# Altium schematic sheet JacdacPinHeaders 45.SchDoc
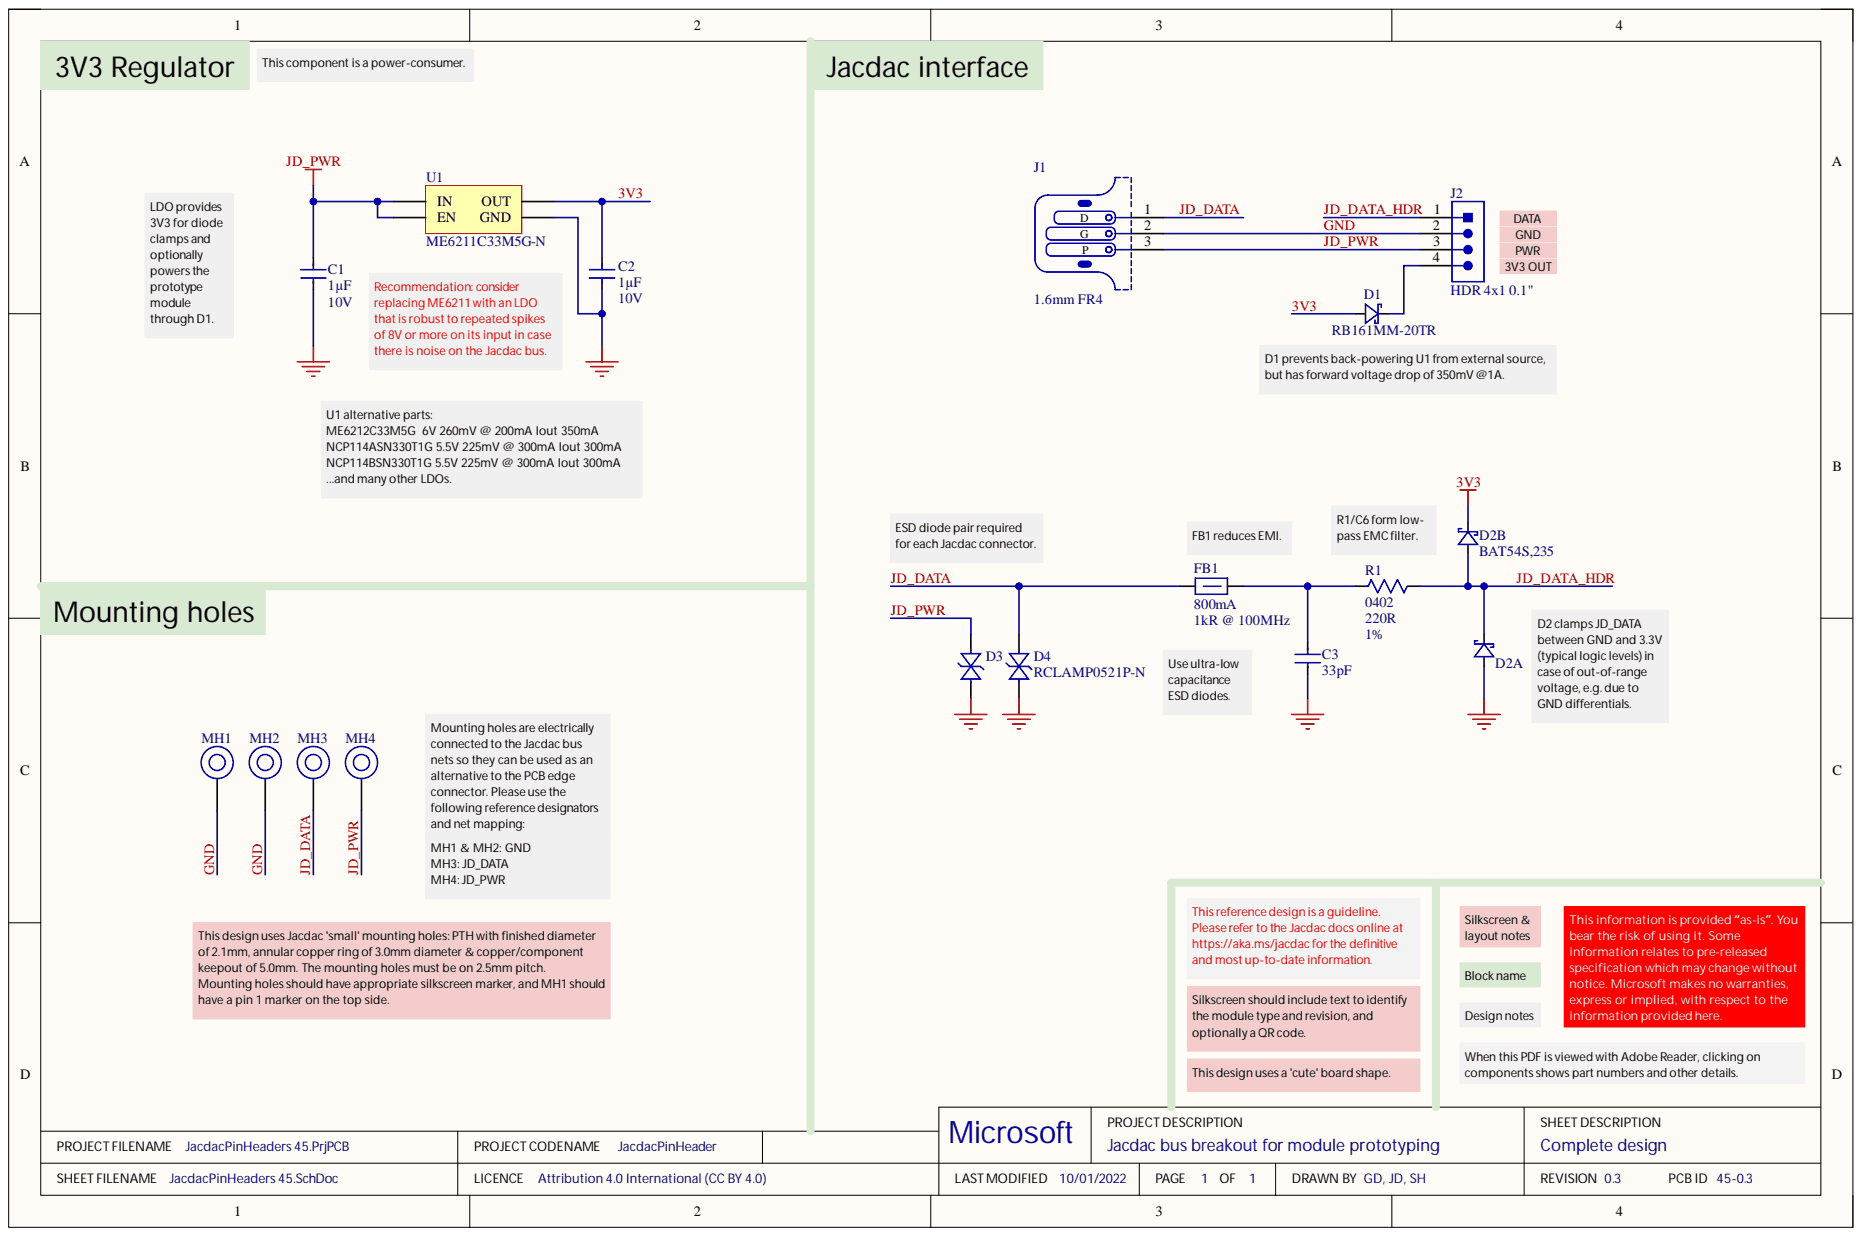
[diagram p0: JacdacPinHeaders 45.SchDoc]

## Parts
C1  Capacitance: 1µF  Voltage Rating: 10V  [C0402_0.55MM - without outline]  (hidden comment: CL05A105KP5NNNC)
C2  Capacitance: 1µF  Voltage Rating: 10V  [C0402_0.55MM - without outline]  (hidden comment: CL05A105KP5NNNC)
C3  Capacitance: 33pF  [C0402_0.55MM - without outline]  (hidden comment: CL05C330JB51PNC)
D1  RB161MM-20TR  [SOD-123F]
D2  BAT54S  Manufacturer Part Number: BAT54S,235  [NXP-BAT54S-TO-236AB - without outline]  (parts A,B)
D3  RCLAMP0521P-N  [TVS 0402 - L]
D4  RCLAMP0521P-N  [TVS 0402 - L]
FB1  Current Rating: 800mA  [TDK MPZ1608 0.8mm - without outline]  (hidden comment: TDK MPZ1608S102ATA00)
J1  PCB Type: 1.6mm FR4  [JACDAC_EDGE_CONNECTOR_NO_TRACES]  (hidden comment: 3 pin JACDAC edge connector)  (no BOM)
J2  HDR 4x1 0.1"  [Generic 1x4 2.54mm header]
MH1  MH_5.0MMK_3.0MMC_2.1MMD_PTH  [MH_5.0MMK_3.0MMC_2.1MMD_PTH]  (value not drawn)  (no BOM)
MH2  MH_5.0MMK_3.0MMC_2.1MMD_PTH  [MH_5.0MMK_3.0MMC_2.1MMD_PTH]  (value not drawn)  (no BOM)
MH3  MH_5.0MMK_3.0MMC_2.1MMD_PTH  [MH_5.0MMK_3.0MMC_2.1MMD_PTH]  (value not drawn)  (no BOM)
MH4  MH_5.0MMK_3.0MMC_2.1MMD_PTH  [MH_5.0MMK_3.0MMC_2.1MMD_PTH]  (value not drawn)  (no BOM)
R1  Case Code (Imperial): 0402  Resistance: 220R  [R0402 - Without outline]  (hidden comment: RC0402FR-07220RL)
U1  ME6211C33M5G-N  [MICRONE-SOT23-5]

## Nets
3V3: C2.2 D1.2 D2.2 U1.5(OUT)
GND: C1.1 C2.1 C3.1 D2.1 D3.2 D4.2 J1.2 J2.2 MH1.1 MH2.1 U1.2(GND)
JD_DATA: D4.1 FB1.1 J1.1 MH3.1
JD_DATA_HDR: D2.3 J2.1 R1.1
JD_PWR: C1.2 D3.1 J1.3 J2.3 MH4.1 U1.1(IN) U1.3(EN)
NetC3_2: C3.2 FB1.2 R1.2
NetD1_1: D1.1 J2.4

## Library data of the parts
C1 C2 = CL05A105KP5NNNC: CAP CER 1UF 10V X5R 0402; Manufacturer: Samsung; Material: Ceramic; Tolerance: 10%; Case Code (Imperial): 0402; Case Code (Metric): 1005; Case/Package: 0402; Category: Capacitors; Component Kind: Standard; ComponentLink1Description: Online datasheet; ComponentLink1URL: https://datasheet.ciiva.com/15195/getdatasheetpartid-358390-15195630.pdf; Contact Plating: Tin; Depth: 0.5mm; Dielectric: X5R; Height: 0.5mm; Length: 1mm; Library Name: Samsung.SchLib; Manufacturer Part Number: CL05A105KP5NNNC; Max Operating Temperature: 85°C; Min Operating Temperature: -55°C; Mount: Surface Mount; Package Quantity: 1; Packaging: Tape and Reel; Pricing 1: 1=0.10000, 10=0.03900, 50=0.02100, 100=0.01720, 500=0.01228, 1000=0.00965, 2500=0.00877, 5000=0.00807 (USD); Pricing 2: 1=0.10000, 10=0.04200, 100=0.01800, 500=0.01300, 1000=0.01000, 2500=0.00900 (USD); Pricing 3: 10=0.02450, 100=0.02000, 500=0.01310, 2500=0.00920, 5000=0.00810, 10000=0.00640, 50000=0.00490 (GBP); Radiation Hardening: No; RoHS: Yes; Stock 1: 5705569; Stock 2: 928941; Stock 3: 53670; Supplier 1: Digi-Key; Supplier 2: Mouser; Supplier 3: Farnell; Supplier Part Number 1: 1276-1076-1-ND; Supplier Part Number 2: 187-CL05A105KP5NNNC; Supplier Part Number 3: 3013329; Thickness: 0.022inch; Width: 0.02inch; Checked: ?; Created: DG
C3 = CL05C330JB51PNC: CAP CER 33PF 50V NP0 0402; Manufacturer: Samsung; Tolerance: 5%; Case Code (Imperial): 0402; Case Code (Metric): 1005; Case/Package: 0402; Category: Capacitors; Component Kind: Standard; ComponentLink1Description: Samsung datasheet online; ComponentLink1URL: http://www.samsungsem.com/kr/support/library/product-catalog/mlcc.jsp; Lead Free: Lead Free; Length: 0.039inch; Library Name: Samsung.SchLib; Manufacturer Part Number: CL05C330JB51PNC; Max Operating Temperature: 125°C; Min Operating Temperature: -55°C; Mount: Surface Mount; Packaging: Tape and Reel; Pricing 1: 1=0.10000, 10=0.07500, 50=0.04060, 100=0.03320, 500=0.02374, 1000=0.01865, 2500=0.01696, 5000=0.01560 (USD); Pricing 2: 1=0.11000, 10=0.08200, 100=0.03600, 500=0.02600, 1000=0.02000, 2500=0.01800 (USD); Pricing 3: 10000=0.00780 (USD); Ratings: AEC-Q200; RoHS: Yes; Stock 1: 250654; Stock 2: 97900; Stock 3: 100000; Supplier 1: Digi-Key; Supplier 2: Mouser; Supplier 3: Future Electronics; Supplier Part Number 1: 1276-6515-1-ND; Supplier Part Number 2: 187-CL05C330JB51PNC; Supplier Part Number 3: 6022520; Thickness: 0.022inch; Voltage Rating: 50V; Width: 0.02inch; Checked: ?; Created: DG
D1 = RB161MM-20TR: ROHM - RB161MM-20TR - Schottky-Gleichrichterdiode, 20 V, 1 A, Einfach, SOD-123FL, 2 Pin(s), 350 mV; Average Rectified Current: 1A; Max Reverse Voltage (DC): 25V; Case/Package: SOD; Category: Rectifier Diodes; Component Kind: Standard; ComponentLink1Description: Online datasheet; ComponentLink1URL: http://www.mouser.com/ds/2/348/rb161mm-20tr-e-1138856.pdf; Library Name: Rohm.SchLib; Manufacturer: Rohm; Manufacturer Part Number: RB161MM-20TR; Max Operating Temperature: 125°C; Mount: Surface Mount; Number of Pins: 2; Packaging: Tape and Reel; Pricing 1: 3000=0.09900, 6000=0.09350, 15000=0.08525, 30000=0.07975, 75000=0.07500 (USD); Pricing 2: 30=0.29200, 150=0.15800, 300=0.15300, 750=0.14900, 1500=0.14500 (USD); Pricing 3: 1=0.33000, 10=0.25100, 50=0.25100, 100=0.12500, 1000=0.10000, 10000=0.07600 (USD); RoHS: Yes; RoHS Compliant: Yes; Stock 2: 1; Supplier 1: Digi-Key; Supplier 2: RSComponents; Supplier 3: Mouser; Supplier Part Number 1: RB161MM-20TR-ND; Supplier Part Number 2: 1246775; Supplier Part Number 3: 755-RB161MM-20TR
D2 = BAT54S: DIODE ARRAY SCHOTTKY 30V SOT23; Manufacturer: Nexperia; Category: Rectifier Diodes; Component Kind: Standard; ComponentLink1Description: NXP datasheet online; ComponentLink1URL: https://assets.nexperia.com/documents/data-sheet/BAT54_SER.pdf; Library Name: NXP.SchLib; Pricing 1: 1=0.13000, 10=0.11900, 100=0.05610, 500=0.03326, 1000=0.02185, 5000=0.02138 (USD); RoHS: No; Stock 1: 14011; Supplier 1: Digi-Key; Supplier 2: Mouser; Supplier 3: RSComponents; Supplier Part Number 1: 1727-1868-1-ND; Supplier Part Number 2: 771-BAT54S235; Supplier Part Number 3: 1707990
D3 D4 = RCLAMP0521P-N: Bidirectional 5V (Max) 6V 16V 3.5A (8/20us) 0402 TVS RoHS; Manufacturer: Born Semiconductor; Component Kind: Standard; ComponentLink1Description: Online datasheet; ComponentLink1URL: https://datasheet.lcsc.com/szlcsc/Bourne-Semicon-Shenzhen-RCLAMP0521P-N_C316043.pdf; Library Name: BornSemiconductor.SchLib; Manufacturer Part Number: RCLAMP0521P-N; Package: 0402; Supplier1: LCSC; Supplier1 Part Number: C316043; Checked By: ?; Created By: D Gakure
FB1 = TDK MPZ1608S102ATA00: 0603 1k@100MHz 0.8A chip; Core Material: Ferrite; DC Resistance (DCR): 300mR; Impedance: 1kR @ 100MHz; Tolerance: 25%; Case Code (Imperial): 0603; Case Code (Metric): 1608; Case/Package: 0603; Component Kind: Standard; ComponentLink2Description: datasheet online; ComponentLink2URL: https://www.mouser.co.uk/datasheet/2/400/eads_commercial_power_mpz1608_en-923650.pdf; ComponentLink3Description: datasheet; Height: 0.037inch; Length: 0.063inch; Library Name: TDK.SchLib; Manufacturer: TDK; Manufacturer Part Number: MPZ1608S102ATA00; Max DC Current: 800mA; Mount: Surface Mount; Package Quantity: 4000; Packaging: Tape and Reel; Pricing 1: 1=0.1, 10=0.079, 25=0.0716, 50=0.0644, 100=0.0472, 250=0.03432, 500=0.03146, 1000=0.02717 (USD); Stock 1: 113542; Supplier 1: Digi-Key; Supplier 2: Mouser; Supplier Part Number 1: 445-8694-1-ND; Supplier Part Number 2: 810-MPZ1608S102ATA00; Width: 0.031inch; ComponentLink3URL: Y:\System\Altium\Libraries 2014\TDK\Datasheets\eads_commercial_power_mpz1608_en-923650.pdf
J1 = Jacdac edge connector: 3 pin Jacdac edge connector 1.27mm pitch; Manufacturer Part Number: JACDAC_EDGE_CONNECTOR; ComponentLink1Description: Yuliang JACDAC cable connector drawing online; ComponentLink1URL: https://github.com/microsoft/jacdac-mdk/blob/main/connector/JACDAC-Connector-by-Yuliang-2020-11-28.pdf; ComponentLink2Description: JACDAC PCB edge connector spec onlin; ComponentLink2URL: https://github.com/microsoft/jacdac-mdk/blob/main/connector/JACDAC_PCB_Edge_Connector_Drawing_JD-PEC-01_rev_01.pdf; Library Name: generic-con.SchLib; Checked By: ?; Created By: D. Gakure
J2 = Header Multicomp 2211S-04G-whitesch: 2.54mm, 4x1 way, vertical, square pin, gold plate; Contact Resistance: 20; Contact Termination: Solder; Contact Termination Type: Through Hole; Current Rating: 3; Gender: Header; Insulation Resistance: 1000; No. of Contacts: 4; No. of Rows: 1; Pitch Spacing: 2.54; Product Range: 2211; SVHC: No SVHC (17-Dec-2015); Voltage Rating V AC: 250; Withstand Voltage: 600V<!--FANDB--><div class="features-and-benefits-container"><div class="features-and-benefits-description"><span class="features-benefits-value">The 2211S-04G is a 4-pin 1-row PCB Header with gold plated copper alloy contacts and a black high temperature plastic (PBT) insulator. This board-to-board holder is ideal for through hole vertical mounting.</span></div><div class="features-and-benefits-list"><ul class="features-and-benefits-list-list"><li class="features-and-benefits-list-item">3A AC/DC Rated current</li><li class="features-and-benefits-list-item">250VAC/DC Rated voltage</li><li class="features-and-benefits-list-item">20; Component Kind: Standard; ComponentLink1Description: header strip datasheet; ComponentLink1URL: http://www.farnell.com/datasheets/1518407.pdf; Contact Material: Copper Alloy; Contact Plating: Gold Plated Contacts; Flammability Rating: UL94V-0; Lead Spacing: 2.54; Library Name: generic-con.SchLib; Manufacturer: Multicomp; Manufacturer Part Number: 2211S-04G; Operating Temperature Max: 105; Operating Temperature Min: -40; Pricing 1: 1=0.0973, 5=0.0918, 25=0.0864, 50=0.0808, 100=0.0753, 250=0.032 (GBP); RoHS: YES; Stock 1: 2760; Supplier 1: Farnell; Supplier Part Number 1: 1593413; Termination Method: Solder; Created By: HUGHWI
MH1-MH4 = MH_5.0MMK_3.0MMC_2.1MMD_PTH: Mounting Hole 5.0mm keepout, 3.0mm annualar ring and 2.1mm Drill PTH; Library Name: generic.SchLib
R1 = RC0402FR-07220RL: RES SMD 220 OHM 1% 1/16W 0402; Manufacturer: Yageo; Max Power Dissipation: 63mW; Power Rating: 62.5mW; Temperature Coefficient: 100ppm/°C; Voltage Rating: 50V; Case Code (Metric): 1005; Case/Package: 0402; Category: Resistors; Component Kind: Standard; ComponentLink1Description: https://datasheet.ciiva.com/11819/0900766b80c66297-11819466.pdf?src-supplier=RSComponents; ComponentLink1URL: https://datasheet.ciiva.com/11819/0900766b80c66297-11819466.pdf?src-supplier=RSComponents; ComponentLink2Description: https://datasheet.ciiva.com/2453/pyu-r-int-thick-7-2453460.pdf?src-supplier=Digi-Key; ComponentLink2URL: https://datasheet.ciiva.com/2453/pyu-r-int-thick-7-2453460.pdf?src-supplier=Digi-Key; ComponentLink3Description: https://datasheet.ciiva.com/2453/pyu-r-int-thick-7-2453461.pdf?src-supplier=Digi-Key; ComponentLink3URL: https://datasheet.ciiva.com/2453/pyu-r-int-thick-7-2453461.pdf?src-supplier=Digi-Key; ComponentLink4Description: https://datasheet.ciiva.com/2453/pyu-r-int-thick-7-2453462.pdf?src-supplier=Digi-Key; ComponentLink4URL: https://datasheet.ciiva.com/2453/pyu-r-int-thick-7-2453462.pdf?src-supplier=Digi-Key; ComponentLink5Description: https://datasheet.ciiva.com/16746/pyu-rc0402-51-rohs-l-6-r-16746831.pdf?src-supplier=Future+Electronics; ComponentLink5URL: https://datasheet.ciiva.com/16746/pyu-rc0402-51-rohs-l-6-r-16746831.pdf?src-supplier=Future+Electronics; Depth: 0.5mm; Features: Moisture Resistant; Height: 0.016inch; Lead Free: Lead Free; Length: 1mm; Library Name: Yageo.SchLib; Manufacturer Part Number: RC0402FR-07220RL; Max Operating Temperature: 155°C; Military Standard: Not; Min Operating Temperature: -55°C; Number of Pins: 2; Number of Terminations: 2; Package Quantity: 1; Packaging: Tape and Reel; Pricing 1: 1=0.10000, 10=0.01400, 100=0.00580, 1000=0.00262, 2500=0.00228, 5000=0.00188 (USD); Pricing 2: 1=0.10000, 10=0.01200, 100=0.00400, 1000=0.00400, 2500=0.00400, 10000=0.00300 (USD); Pricing 3: 1=0.08130, 25=0.01210, 100=0.01120, 250=0.01030, 500=0.00900 (GBP); REACH SVHC: No SVHC; Resistor Type: Thick Film; RoHS: Yes; Stock 1: 1286042; Stock 2: 324625; Stock 3: 18234; Supplier 1: Digi-Key; Supplier 2: Mouser; Supplier 3: Farnell; Supplier Part Number 1: 311-220LRCT-ND; Supplier Part Number 2: 603-RC0402FR-07220RL; Supplier Part Number 3: 2147864; Termination: SMD/SMT; Tolerance: 1%; Voltage Rating (DC): 50V; Width: 0.02inch; Checked: ?; Created: D.G
U1 = ME6211C33M5G-N: 6V 260mV @ 200mA(typ) - - Fixed 3.3V SOT-23-5 Linear Voltage Regulators (LDO) ROHS; manufacturer: MICRONE(Nanjing Micro One Elec); Dropout Voltage: 200mV; Max Input Voltage: 6; Number of Regulators: 1; Output Configuration: Positive; Output Current: 500mA; Case/Package: SOT-23-5; Category: Voltage Regulators - Linear; Component Kind: Standard; ComponentLink3Description: On Semi datasheet online; ComponentLink3URL: https://datasheet.lcsc.com/lcsc/1811131510_MICRONE-Nanjing-Micro-One-Elec-ME6211C33M5G-N_C82942.pdf; Library Name: MICRONE(NanjingMicroOneElec).SchLib; Manufacturer Part Number: ME6211C33M5G-N; Min Output Voltage: 3.3V; Mount: Surface Mount; Output Type: Fixed; Pricing 1: 1=0.33000, 10=0.24900, 25=0.21760, 100=0.12440, 250=0.11664, 500=0.09330, 1000=0.07153 (USD); Stock 1: 32529; Supplier 1: LCSC; Supplier Part Number: C82942; Checked: ?; Created: DG
